# Altium schematic sheet [11] - MOSFET.SchDoc
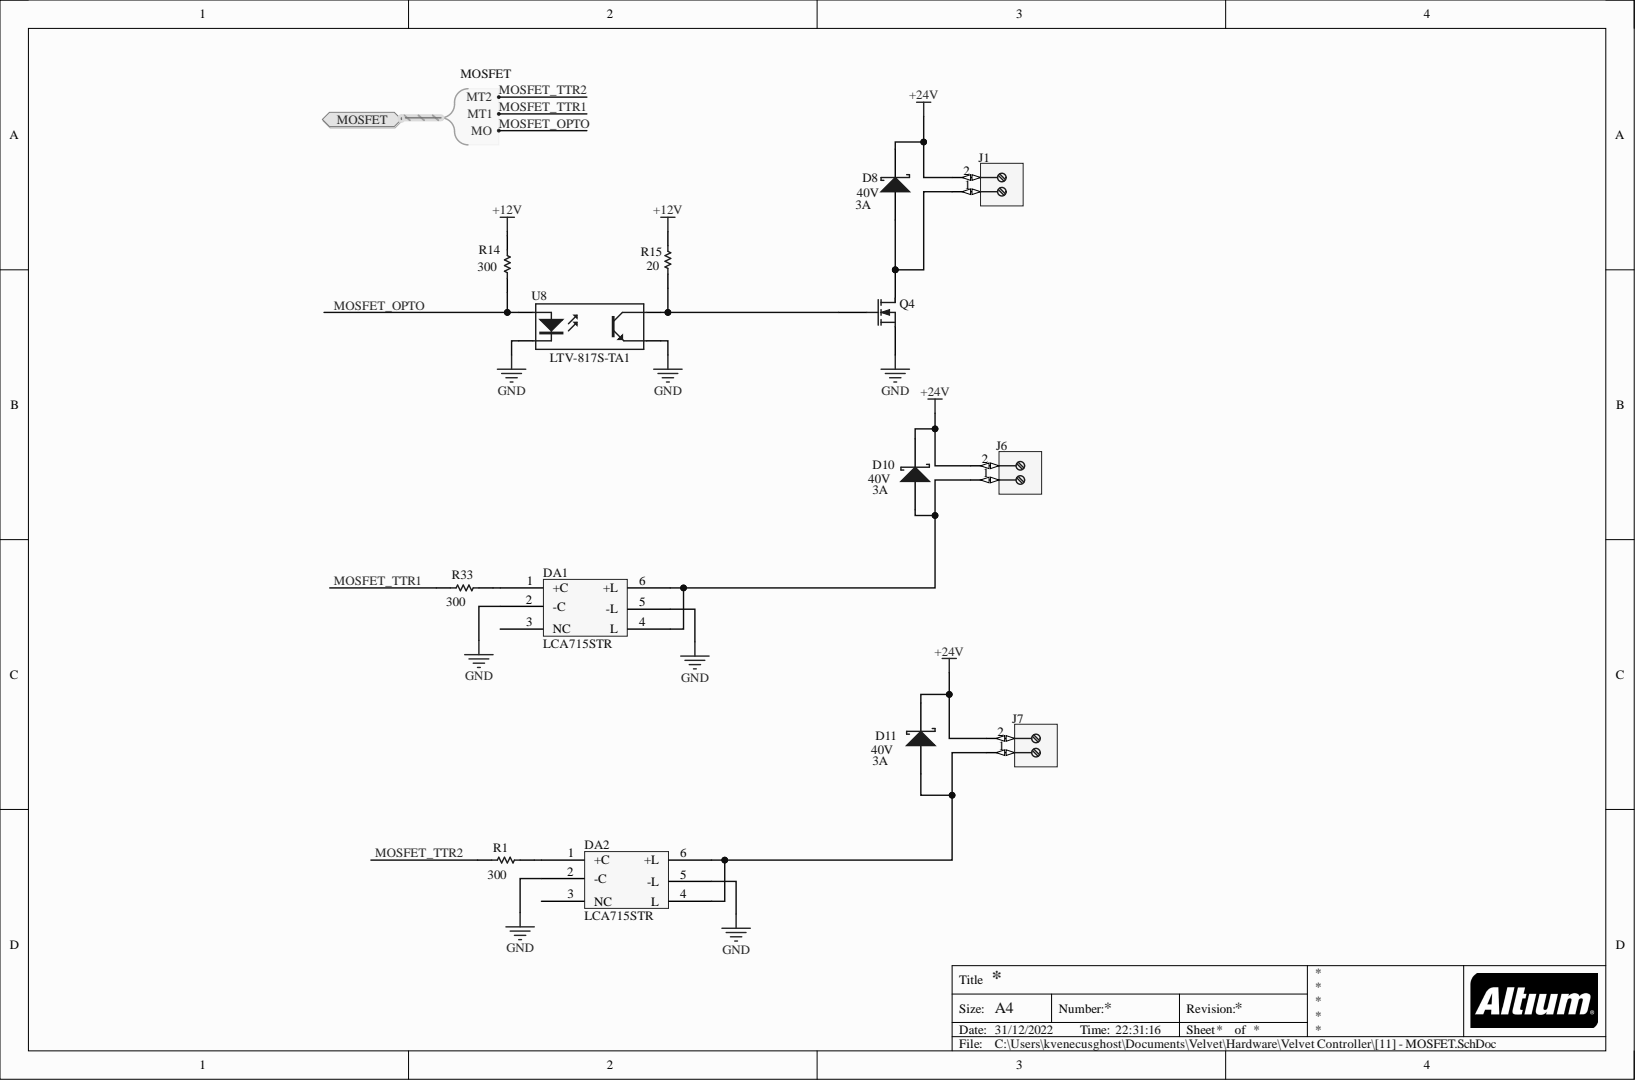
[diagram p0: [11] - MOSFET.SchDoc]

## Parts
D8  Average Rectified Current: 3A  Max Repetitive Reverse Voltage (Vrrm): 40V  [D-Schottky]  (hidden comment: SK32)
D10  Average Rectified Current: 3A  Max Repetitive Reverse Voltage (Vrrm): 40V  [D-Schottky]  (hidden comment: SK32)
D11  Average Rectified Current: 3A  Max Repetitive Reverse Voltage (Vrrm): 40V  [D-Schottky]  (hidden comment: SK32)
DA1  LCA715STR  [6-SMD]
DA2  LCA715STR  [6-SMD]
J1  (no value)  [PHOENIX_1715721]
J6  (no value)  [PHOENIX_1715721]
J7  (no value)  [PHOENIX_1715721]
Q4  MOSFET-N  [D-PAK (TO-252)]  (value not drawn)
R1  value 300  [0603_R]  (hidden comment: Res1)
R14  Res1  [0603_R]  (value not drawn)
R15  Res1  [0603_R]  (value not drawn)
R33  value 300  [0603_R]  (hidden comment: Res1)
U8  Manufacturer Part Number: LTV-817S-TA1  [LTV-817S_LTO-L]  (hidden comment: Optoisolator1)

## Ports
MOSFET, MOSFET.MO, MOSFET.MT1, MOSFET.MT2

## Nets
+12V: R14.2 R15.2
+24V: D8.2 D10.2 D11.2 J1.2 J6.2 J7.2
GND: DA1.2(-C) DA1.5(-L) DA2.2(-C) DA2.5(-L) Q4.3 U8.2 U8.3
MOSFET_OPTO: R14.1 U8.1 ; port MOSFET
MOSFET_TTR1: R33.2 ; port MOSFET
MOSFET_TTR2: R1.2 ; port MOSFET
NetD8_1: D8.1 J1.1 Q4.2
NetD10_1: D10.1 DA1.4(L) DA1.6(+L) J6.1
NetD11_1: D11.1 DA2.4(L) DA2.6(+L) J7.1
NetDA1_1: DA1.1(+C) R33.1
NetDA2_1: DA2.1(+C) R1.1
NetQ4_1: Q4.1 R15.1 U8.4

Not connected: DA1 3(NC); DA2 3(NC)

## Library data of the parts
D8 D10 D11 = SK32: Schottky Rectifier; Manufacturer: Diodes; Manufacturer Part Number: B340A-13-F; Capacitance: 250pF; Element Configuration: Single; Forward Voltage: 500mV; Max Forward Surge Current (Ifsm): 80A; Peak Reverse Current: 500uA; Polarity: Standard; Case/Package: SMA; Component Kind: Standard; ComponentLink1Description: Manufacturer Link; ComponentLink1URL: http://www.irf.com/; ComponentLink2Description: Datasheet; ComponentLink2URL: http://www.irf.com/product-info/datasheets/data/18tq.pdf; ComponentLink3Description: https://datasheet.ciiva.com/4245/861ds30891-4245763.pdf; ComponentLink3URL: https://datasheet.ciiva.com/4245/861ds30891-4245763.pdf; ComponentLink4Description: https://datasheet.ciiva.com/26949/getdatasheetpartid-143394-26949352.pdf; ComponentLink4URL: https://datasheet.ciiva.com/26949/getdatasheetpartid-143394-26949352.pdf; ComponentLink5Description: https://datasheet.ciiva.com/1177/ds30891-1177476.pdf; ComponentLink5URL: https://datasheet.ciiva.com/1177/ds30891-1177476.pdf; ComponentLink6Description: https://datasheet.ciiva.com/1240/ds30891-1240228.pdf; ComponentLink6URL: https://datasheet.ciiva.com/1240/ds30891-1240228.pdf; ComponentLink7Description: https://datasheet.ciiva.com/913/ds30891-913637.pdf; ComponentLink7URL: https://datasheet.ciiva.com/913/ds30891-913637.pdf; ComponentLink8Description: https://datasheet.ciiva.com/913/ds30891-913638.pdf; ComponentLink8URL: https://datasheet.ciiva.com/913/ds30891-913638.pdf; ComponentLink9Description: https://datasheet.ciiva.com/913/ds30891-913639.pdf; ComponentLink9URL: https://datasheet.ciiva.com/913/ds30891-913639.pdf; ComponentLink10Description: https://datasheet.ciiva.com/46393/1911813-46393773.pdf; ComponentLink10URL: https://datasheet.ciiva.com/46393/1911813-46393773.pdf; Contact Plating: Tin,Matte; Current: 3A; Current Rating: 3A; Forward Current: 3A; Height: 2.3mm; Lead Free: Lead Free; Length: 4.6mm; Max Operating Temperature: 125°C; Max Reverse Voltage (DC): 40V; Min Operating Temperature: -55°C; Mount: Surface Mount; Number of Pins: 2; Output Current: 3A; Package Quantity: 5000; Packaging: Tape and Reel; Peak Non-Repetitive Surge Current: 80A; Pricing 1: 1=0.46, 10=0.373, 100=0.2538, 500=0.19034, 1000=0.14276 (USD); Radiation Hardening: No; REACH SVHC: No SVHC; Stock 1: 761735; Supplier 1: Digi-Key; Supplier Part Number 1: B340A-FDIDKR-ND; Termination: SMD/SMT; Voltage: 40V; Voltage Rating (DC): 40V; Weight: 0.002258oz; Width: 2.92mm; Library Name: GSMInformer-PB-TC.v1.0-2013.SchLib
DA1 DA2 = LCA715STR: LCA715S-TTP; Component Kind: Standard; Library Name: Blue Tractor-2021.SchLib
J1 J6 J7 = Connection 2 PIN: Connection -POENIX; Manufacturer: PHOENIX CONTACT; Manufacturer Part Number: 1715721 (MKDS 1,5/2-5,08); Part Description: 17.5A, 400V, Terminal Block, Pitch 5.08mm, 2 Positions, Screw Connection; PressFit: No; Component Kind: Standard; Component Type: Standard; ComponentHeight: 13.8mm; CreateDate: 06.01.2010; HelpURL: \\cern.ch\dfs\Applications\Altium\Datasheets\PHOENIX_1715721.pdf; LatestRevisionDate: 25.02.2013; Mounted: Yes; Part Number: PHOENIX_1715721; Pin Count: 2; Sense: No; SMD: No; Socket: No; Status: None; Author: CERN DEM JLC; Library Name: Blue Tractor-2021.SchLib
Q4 = MOSFET-N: N-Channel MOSFET; Component Kind: Standard; LatestRevisionDate: 17-Jul-2002; LatestRevisionNote: Re-released for DXP Platform.; Library Name: Miscellaneous Devices.IntLib; PackageDescription: TO, Flat Index; 3 In-Line, Axial Leads; Body Dia. 4.6mm; Lead Dia. 0.5 mm (max); PackageReference: E3; PackageVersion: 1995/1996; Published: 8-Jun-2000; Simulation: NMOS; Publisher: Altium Limited
R1 R33 = 1: Chip Resistor; Manufacturer: Stackpole Electronics Inc; Manufacturer Part Number: RMCF0603JT3K60; Database Table Name: Resistors$; Power (Watts): 0.1W, 1/10W; Resistance (Ohms): 3.6k; Temperature Coefficient: ±200ppm/°C; Tolerance: ±5%; Category: Resistors; Component Kind: Standard; ComponentLink1Description: http://www.seielect.com/Catalog/SEI-RMCF_RMCP.pdf; ComponentLink1URL: http://www.seielect.com/Catalog/SEI-RMCF_RMCP.pdf; Composition: Thick Film; Features: Automotive AEC-Q200; Height: 0.022" (0.55mm); ID: 1; LatestRevisionDate: 17-Jul-2002; LatestRevisionNote: Re-released for DXP Platform.; Library Name: Altium_Library.DbLib; Number of Terminations: 2; Operating Temperature: -55°C ~ 155°C; Package / Case: 0603 (1608 Metric); PackageDescription: Axial Device, Thru-Hole; 2 Leads; 0.3 in Pin Spacing; PackageReference: AXIAL-0.3; Packaging: Cut Tape (CT); Pricing 1: 1=0.1, 10=0.017, 50=0.0092, 100=0.007, 250=0.0052, 500=0.00384, 1000=0.00256, 2500=0.00216 (USD); Published: 8-Jun-2000; RoHS: RoHS Compliant; Size / Dimension: 0.061" L x 0.031" W (1.55mm x 0.80mm); Stock 1: 6270; Supplier 1: Digi-Key; Supplier Device Package: 0603; Supplier Part Number 1: RMCF0603JT3K60CT-ND; Publisher: Altium Limited
R14 = 1: Chip Resistor; Manufacturer: Panasonic; Manufacturer Part Number: ERJ-3GEYJ222V; Database Table Name: Resistors$; Max Power Dissipation: 100mW; Resistance: 300; Temperature Coefficient: 200ppm/°C; Tolerance: 5%; Voltage Rating: 75V; Voltage Rating (DC): 50V; Case Code (Imperial): 0603; Case Code (Metric): 1608; Case/Package: 0603; Component Kind: Standard; ComponentLink1Description: https://datasheet.ciiva.com/11821/0900766b80f806dd-11821453.pdf; ComponentLink1URL: https://datasheet.ciiva.com/11821/0900766b80f806dd-11821453.pdf; ComponentLink2Description: https://datasheet.ciiva.com/34303/1988639-34303614.pdf; ComponentLink2URL: https://datasheet.ciiva.com/34303/1988639-34303614.pdf; ComponentLink3Description: https://datasheet.ciiva.com/16760/70255313-16760341.pdf; ComponentLink3URL: https://datasheet.ciiva.com/16760/70255313-16760341.pdf; ComponentLink4Description: https://datasheet.ciiva.com/9117/aoa0000ce1-9117127.pdf; ComponentLink4URL: https://datasheet.ciiva.com/9117/aoa0000ce1-9117127.pdf; ComponentLink5Description: https://datasheet.ciiva.com/46380/1500035-46380411.pdf; ComponentLink5URL: https://datasheet.ciiva.com/46380/1500035-46380411.pdf; ComponentLink6Description: https://datasheet.ciiva.com/16765/70255313-16765244.pdf; ComponentLink6URL: https://datasheet.ciiva.com/16765/70255313-16765244.pdf; ComponentLink7Description: https://datasheet.ciiva.com/16199/aoa0000ce1-16199902.pdf; ComponentLink7URL: https://datasheet.ciiva.com/16199/aoa0000ce1-16199902.pdf; ComponentLink8Description: https://datasheet.ciiva.com/16853/aoa0000ce1-16853407.pdf; ComponentLink8URL: https://datasheet.ciiva.com/16853/aoa0000ce1-16853407.pdf; ComponentLink9Description: https://datasheet.ciiva.com/22758/1500035-22758223.pdf; ComponentLink9URL: https://datasheet.ciiva.com/22758/1500035-22758223.pdf; ComponentLink10Description: https://datasheet.ciiva.com/28584/1678796-28584095.pdf; ComponentLink10URL: https://datasheet.ciiva.com/28584/1678796-28584095.pdf; Composition: Thick Film; Contact Plating: Tin; Depth: 0.8mm; Features: Automotive AEC-Q200; Height: 0.45mm; Lead Free: Lead Free; Length: 1.6mm; Library Name: Altium_Library.DbLib; Max Operating Temperature: 155°C; Military Standard: Not; Min Operating Temperature: -55°C; Mount: Surface Mount; Number of Terminations: 2; Package Quantity: 1; Packaging: Tape and Reel; Power Rating: 100mW; Pricing 1: 1=0.1, 10=0.054, 100=0.022, 1000=0.00988, 2500=0.00858 (USD); Radiation Hardening: No; REACH SVHC: No SVHC; Stock 1: 1578287; Supplier 1: Digi-Key; Supplier Part Number 1: P2.2KGDKR-ND; Termination: SMD/SMT; Width: 0.8mm; Working Voltage: 75V
R15 = 1: Chip Resistor; Manufacturer: Panasonic; Manufacturer Part Number: ERJ-3GEYJ222V; Database Table Name: Resistors$; Max Power Dissipation: 100mW; Resistance: 20; Temperature Coefficient: 200ppm/°C; Tolerance: 5%; Voltage Rating: 75V; Voltage Rating (DC): 50V; Case Code (Imperial): 0603; Case Code (Metric): 1608; Case/Package: 0603; Component Kind: Standard; ComponentLink1Description: https://datasheet.ciiva.com/11821/0900766b80f806dd-11821453.pdf; ComponentLink1URL: https://datasheet.ciiva.com/11821/0900766b80f806dd-11821453.pdf; ComponentLink2Description: https://datasheet.ciiva.com/34303/1988639-34303614.pdf; ComponentLink2URL: https://datasheet.ciiva.com/34303/1988639-34303614.pdf; ComponentLink3Description: https://datasheet.ciiva.com/16760/70255313-16760341.pdf; ComponentLink3URL: https://datasheet.ciiva.com/16760/70255313-16760341.pdf; ComponentLink4Description: https://datasheet.ciiva.com/9117/aoa0000ce1-9117127.pdf; ComponentLink4URL: https://datasheet.ciiva.com/9117/aoa0000ce1-9117127.pdf; ComponentLink5Description: https://datasheet.ciiva.com/46380/1500035-46380411.pdf; ComponentLink5URL: https://datasheet.ciiva.com/46380/1500035-46380411.pdf; ComponentLink6Description: https://datasheet.ciiva.com/16765/70255313-16765244.pdf; ComponentLink6URL: https://datasheet.ciiva.com/16765/70255313-16765244.pdf; ComponentLink7Description: https://datasheet.ciiva.com/16199/aoa0000ce1-16199902.pdf; ComponentLink7URL: https://datasheet.ciiva.com/16199/aoa0000ce1-16199902.pdf; ComponentLink8Description: https://datasheet.ciiva.com/16853/aoa0000ce1-16853407.pdf; ComponentLink8URL: https://datasheet.ciiva.com/16853/aoa0000ce1-16853407.pdf; ComponentLink9Description: https://datasheet.ciiva.com/22758/1500035-22758223.pdf; ComponentLink9URL: https://datasheet.ciiva.com/22758/1500035-22758223.pdf; ComponentLink10Description: https://datasheet.ciiva.com/28584/1678796-28584095.pdf; ComponentLink10URL: https://datasheet.ciiva.com/28584/1678796-28584095.pdf; Composition: Thick Film; Contact Plating: Tin; Depth: 0.8mm; Features: Automotive AEC-Q200; Height: 0.45mm; Lead Free: Lead Free; Length: 1.6mm; Library Name: Altium_Library.DbLib; Max Operating Temperature: 155°C; Military Standard: Not; Min Operating Temperature: -55°C; Mount: Surface Mount; Number of Terminations: 2; Package Quantity: 1; Packaging: Tape and Reel; Power Rating: 100mW; Pricing 1: 1=0.1, 10=0.054, 100=0.022, 1000=0.00988, 2500=0.00858 (USD); Radiation Hardening: No; REACH SVHC: No SVHC; Stock 1: 1578287; Supplier 1: Digi-Key; Supplier Part Number 1: P2.2KGDKR-ND; Termination: SMD/SMT; Width: 0.8mm; Working Voltage: 75V
U8 = Optoisolator1: 4-Pin Phototransistor Optocoupler; Manufacturer: Toshiba; Clamping Voltage: 14.5V; Collector Emitter Saturation Voltage: 300mV; Collector Emitter Voltage (VCEO): 80V; Current Transfer Ratio: 400%; Direction: Unidirectional; Element Configuration: Single; Forward Current: 20mA; Forward Voltage: 1.4V; Isolation Voltage: 3.75kV; Max Collector Current: 50mA; Max Power Dissipation: 200mW; Max Reverse Leakage Current: 20uA; Min Breakdown Voltage: 7.2V; Number of Channels: 1; Peak Pulse Current: 160A; Peak Pulse Power: 400W; Reverse Standoff Voltage: 6.5V; Test Current: 10mA; Case/Package: SMD/SMT; Component Kind: Standard; ComponentLink1Description: https://datasheet.ciiva.com/2086/tlp184-datasheet-en-20140908-2086688.pdf?src-supplier=Digi-Key; ComponentLink1URL: https://datasheet.ciiva.com/2086/tlp184-datasheet-en-20140908-2086688.pdf?src-supplier=Digi-Key; Library Name: Miscellaneous Devices.IntLib; Max Operating Temperature: 110°C; Max Output Voltage: 80V; Min Operating Temperature: -55°C; Mount: Surface Mount; Number of Elements: 1; Number of Pins: 4; Output Current per Channel: 50mA; Package Quantity: 1; Packaging: Tape and Reel; Power Dissipation: 200mW; Pricing 1: 1 = 0,73000, 10 = 0,52800, 25 = 0,43080, 100 = 0,34460, 250 = 0,29076, 500 = 0,24768, 1000 = 0,19384 (USD); Radiation Hardening: No; RoHS: Yes; Stock 1: 8604; Supplier 1: Digi-Key; Supplier Part Number 1: TLP184(TPRE)CT-ND
